# Altium schematic sheet Measure.SchDoc(Measure)
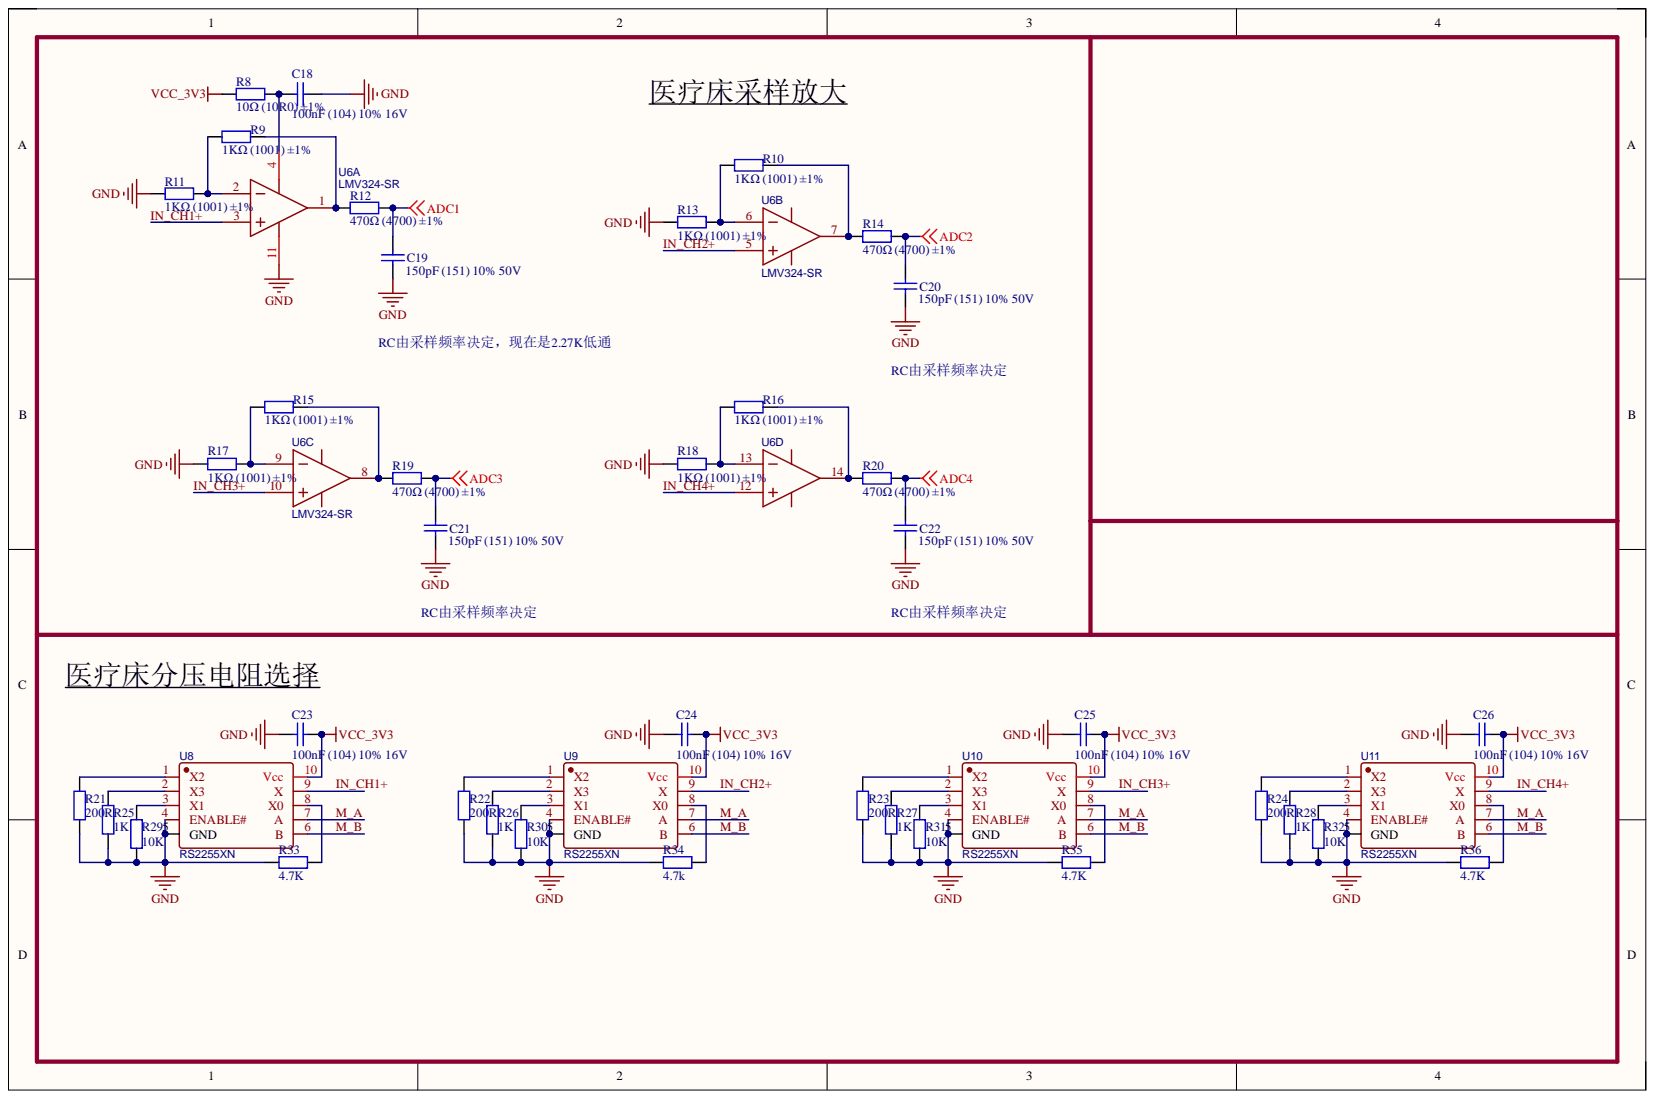
[diagram p0: Measure.SchDoc]

## Parts
C18  100nF (104) 10% 16V  [0402_C]
C19  150pF (151) 10% 50V  [0402_C]
C20  150pF (151) 10% 50V  [0402_C]
C21  150pF (151) 10% 50V  [0402_C]
C22  150pF (151) 10% 50V  [0402_C]
C23  100nF (104) 10% 16V  [0402_C]
C24  100nF (104) 10% 16V  [0402_C]
C25  100nF (104) 10% 16V  [0402_C]
C26  100nF (104) 10% 16V  [0402_C]
R8  10Ω (10R0) ±1%  [0402_R]
R9  1KΩ (1001) ±1%  [0402_R]
R10  1KΩ (1001) ±1%  [0402_R]
R11  1KΩ (1001) ±1%  [0402_R]
R12  470Ω (4700) ±1%  [0402_R]
R13  1KΩ (1001) ±1%  [0402_R]
R14  470Ω (4700) ±1%  [0402_R]
R15  1KΩ (1001) ±1%  [0402_R]
R16  1KΩ (1001) ±1%  [0402_R]
R17  1KΩ (1001) ±1%  [0402_R]
R18  1KΩ (1001) ±1%  [0402_R]
R19  470Ω (4700) ±1%  [0402_R]
R20  470Ω (4700) ±1%  [0402_R]
R21  200R  [0402_R]
R22  200R  [0402_R]
R23  200R  [0402_R]
R24  200R  [0402_R]
R25  1K  [0402_R]
R26  1K  [0402_R]
R27  1K  [0402_R]
R28  1K  [0402_R]
R29  10K  [0402_R]
R30  10K  [0402_R]
R31  10K  [0402_R]
R32  10K  [0402_R]
R33  4.7K  [0402_R]
R34  4.7k  [0402_R]
R35  4.7K  [0402_R]
R36  4.7K  [0402_R]
U6  LMV324-SR  [SOP-14_L8.6-W3.9-P1.27-LS6.0-BL]  (parts A,B,C,D)
U8  RS2255XN  [MSOP-10_L3.0-W3.0-P0.50-LS5.0-BL]
U9  RS2255XN  [MSOP-10_L3.0-W3.0-P0.50-LS5.0-BL]
U10  RS2255XN  [MSOP-10_L3.0-W3.0-P0.50-LS5.0-BL]
U11  RS2255XN  [MSOP-10_L3.0-W3.0-P0.50-LS5.0-BL]

## Nets
ADC1: C19.2 R12.2
ADC2: C20.2 R14.2
ADC3: C21.2 R19.2
ADC4: C22.2 R20.2
GND: C18.2 C19.1 C20.1 C21.1 C22.1 C23.2 C24.2 C25.2 C26.2 R11.1 R13.1 R17.1 R18.1 R21.1 R22.1 R23.1 R24.1 R25.2 R26.2 R27.2 R28.2 R29.2 R30.2 R31.2 R32.2 R33.2 R34.2 R35.2 R36.2 U6.11 U8.4(ENABLE#) U8.5(GND) U9.4(ENABLE#) U9.5(GND) U10.4(ENABLE#) U10.5(GND) U11.4(ENABLE#) U11.5(GND)
VCC_3V3: C23.1 C24.1 C25.1 C26.1 R8.1 U8.10(Vcc) U9.10(Vcc) U10.10(Vcc) U11.10(Vcc)
IN_CH1+: U6.3 U8.9(X)
IN_CH2+: U6.5 U9.9(X)
IN_CH3+: U6.10 U10.9(X)
IN_CH4+: U6.12 U11.9(X)
M_A: U8.7(A) U9.7(A) U10.7(A) U11.7(A)
M_B: U8.6(B) U9.6(B) U10.6(B) U11.6(B)
NetC18_1: C18.1 R8.2 U6.4
NetR9_1: R9.1 R11.2 U6.2
NetR9_2: R9.2 R12.1 U6.1
NetR10_1: R10.1 R13.2 U6.6
NetR10_2: R10.2 R14.1 U6.7
NetR15_1: R15.1 R17.2 U6.9
NetR15_2: R15.2 R19.1 U6.8
NetR16_1: R16.1 R18.2 U6.13
NetR16_2: R16.2 R20.1 U6.14
NetR21_2: R21.2 U8.1(X2)
NetR22_2: R22.2 U9.1(X2)
NetR23_2: R23.2 U10.1(X2)
NetR24_2: R24.2 U11.1(X2)
NetR25_1: R25.1 U8.2(X3)
NetR26_1: R26.1 U9.2(X3)
NetR27_1: R27.1 U10.2(X3)
NetR28_1: R28.1 U11.2(X3)
NetR29_1: R29.1 U8.3(X1)
NetR30_1: R30.1 U9.3(X1)
NetR31_1: R31.1 U10.3(X1)
NetR32_1: R32.1 U11.3(X1)
NetR33_1: R33.1 U8.8(X0)
NetR34_1: R34.1 U9.8(X0)
NetR35_1: R35.1 U10.8(X0)
NetR36_1: R36.1 U11.8(X0)

## Library data of the parts
C18 C23-C26 = 0402 100nF (104) 10% 16V: 贴片电容; Component Kind: Standard; ComponentLink1Description: 供应商链接; ComponentLink1URL: http://www.szlcsc.com/product/details_1877.html; Library Name: Miscellaneous Devices LC.IntLib; Package: 0402; Supplier: LC; SuppliersPartNumber: C1525
C19-C22 = 0402 150pF (151) 10% 50V: 贴片电容; Component Kind: Standard; ComponentLink1Description: 供应商链接; ComponentLink1URL: http://www.szlcsc.com/product/details_1879.html; Library Name: Miscellaneous Devices LC.IntLib; Package: 0402; Supplier: LC; SuppliersPartNumber: C1527
R8 = 0402 10Ω (10R0) 1%: 贴片电阻; Component Kind: Standard; ComponentLink1Description: 供应商链接; ComponentLink1URL: http://www.szlcsc.com/product/details_25820.html; Library Name: Miscellaneous Devices LC.IntLib; Package: 0402; Supplier: LC; SuppliersPartNumber: C25077
R9-R11 R13 R15-R18 R25-R28 = 0402 1KΩ (1001) 1%: 贴片电阻; Component Kind: Standard; ComponentLink1Description: 供应商链接; ComponentLink1URL: http://www.szlcsc.com/product/details_12256.html; Package: 0402; Supplier: LC; SuppliersPartNumber: C11702
  R9-R11 R13 R15-R18: Library Name: Miscellaneous Devices LC.IntLib
  R25-R28: Library Name: 医疗床PCB.SCHLIB
R12 R14 R19 R20 = 0402 470Ω (4700) 1%: 贴片电阻; Component Kind: Standard; ComponentLink1Description: 供应商链接; ComponentLink1URL: http://www.szlcsc.com/product/details_25860.html; Library Name: Miscellaneous Devices LC.IntLib; Package: 0402; Supplier: LC; SuppliersPartNumber: C25117
R21-R24 = 0402 200Ω (2000) 1%: 贴片电阻; Component Kind: Standard; ComponentLink1Description: 供应商链接; ComponentLink1URL: http://www.szlcsc.com/product/details_25830.html; Package: 0402; Supplier: LC; SuppliersPartNumber: C25087; Library Name: 医疗床PCB.SCHLIB
R29-R32 = 0402 10KΩ (1002) 1%: 贴片电阻; Component Kind: Standard; ComponentLink1Description: 供应商链接; ComponentLink1URL: http://www.szlcsc.com/product/details_26487.html; Package: 0402; Supplier: LC; SuppliersPartNumber: C25744; Library Name: 医疗床PCB.SCHLIB
R33-R36 = 0402 4.7KΩ (4701) 1%: 贴片电阻; Component Kind: Standard; ComponentLink1Description: 供应商链接; ComponentLink1URL: http://www.szlcsc.com/product/details_26643.html; Package: 0402; Supplier: LC; SuppliersPartNumber: C25900; Library Name: 医疗床PCB.SCHLIB
U6 = LMV324-SR: BOM_Manufacturer: IDCHIP; BOM_Manufacturer Part: LMV324; subpart_no: 3; BOM_JLCPCB Part Class: Extended Part; BOM_Supplier: LCSC; BOM_Supplier Part: C395458; Component Kind: Standard; spicePre: U; spiceSymbolName: LMV324; Library Name: 医疗床PCB.SCHLIB
U8-U11 = RS2255XN: BOM_Manufacturer: Jiangsu RUNIC Tech; Contributor: LCSC; BOM_JLCPCB Part Class: Extended Part; BOM_Manufacturer Part: RS2255XN; BOM_Supplier: LCSC; BOM_Supplier Part: C783421; Component Kind: Standard; spicePre: U; spiceSymbolName: RS2255XN; Library Name: PCB_Bottom.SchLib
